# Altium schematic sheet vfd.SchDoc
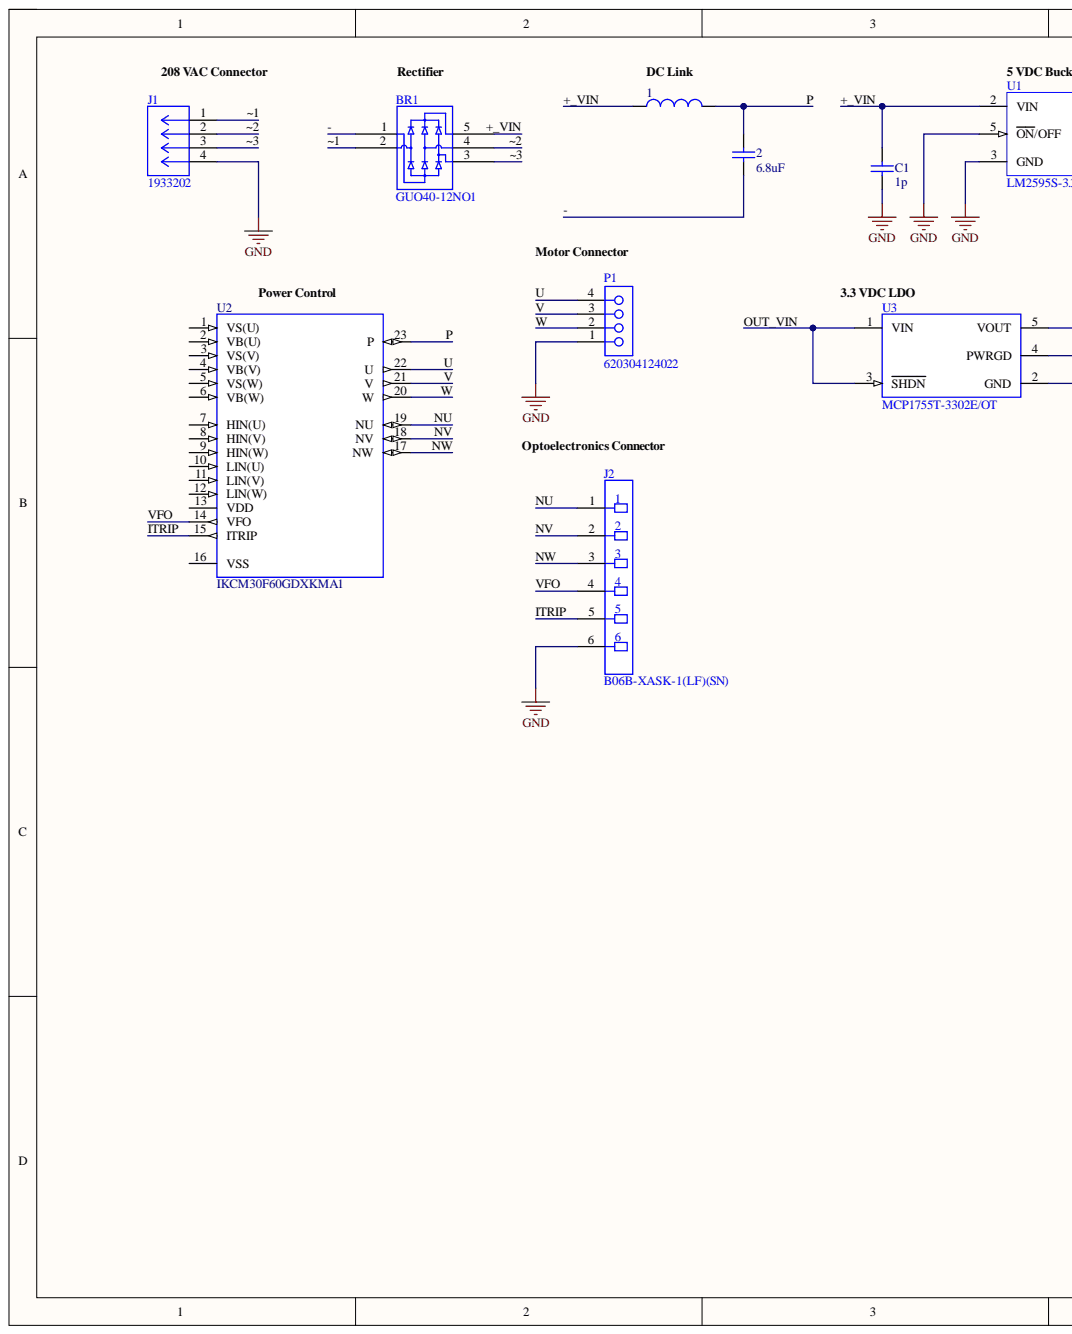
[diagram p0: vfd.SchDoc, left]
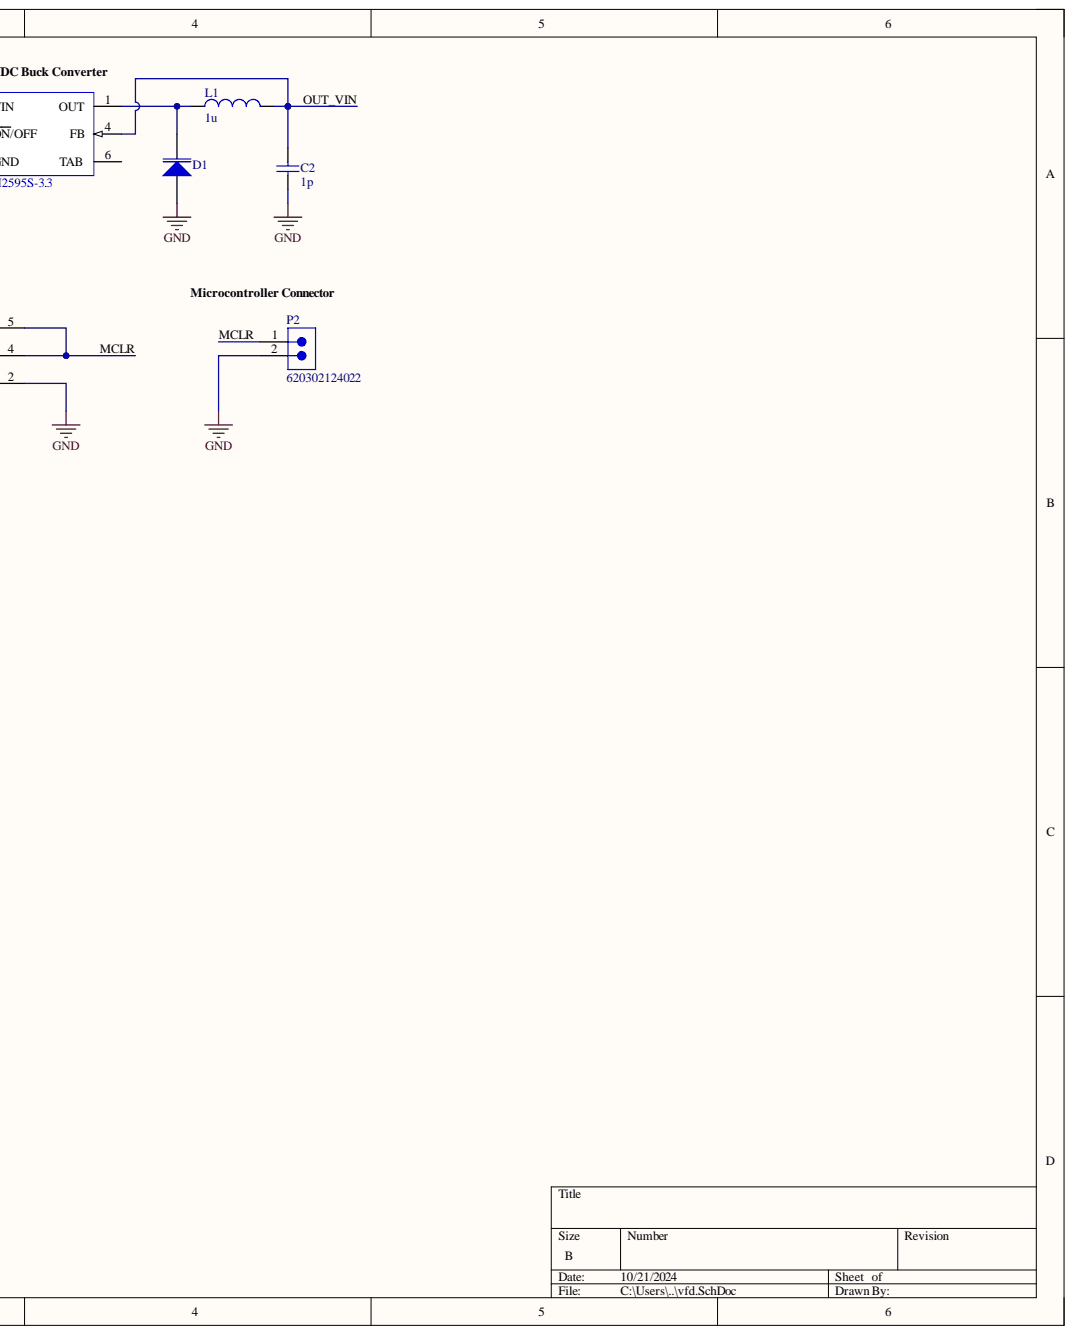
[diagram p1: vfd.SchDoc, right]

## Parts
1  Inductor  (value not drawn)
2  value 6.8uF  (hidden comment: Capacitor)
BR1  GUO40-12NO1  [SIP5-GUFP_LTF]
C1  value 1p  (hidden comment: Capacitor)
C2  value 1p  (hidden comment: Capacitor)
D1  Varactor  (value not drawn)
J1  1933202  [CONN_1933202_PXC]
J2  B06B-XASK-1(LF)(SN)  [FP-B06B-XASK-1_LF_SN-MFG]
L1  value 1u  (hidden comment: Inductor)
P1  620304124022  [620304124022]
P2  620302124022  [620302124022]
U1  LM2595S-3.3  [KTT0005B_L]
U2  IKCM30F60GDXKMA1  [IC_IKCM30F60GDXKMA1]
U3  MCP1755T-3302E/OT  [FP-C04-091-OT-MFG]

## Nets
GND: C1.1 C2.1 D1.3 J1.4 J2.6 P1.1 P2.2 U1.3(GND) U1.5(ON/OFF) U3.2(GND)
+_VIN: 1.1 BR1.5 C1.2 U1.2(VIN)
-: 2.1 BR1.1
ITRIP: J2.5 U2.15(ITRIP)
MCLR: P2.1 U3.4(PWRGD) U3.5(VOUT)
NU: J2.1 U2.19(NU)
NV: J2.2 U2.18(NV)
NW: J2.3 U2.17(NW)
OUT_VIN: C2.2 L1.2 U1.4(FB) U3.1(VIN) U3.3(SHDN)
P: 1.2 2.2 U2.23(P)
U: P1.4 U2.22(U)
V: P1.3 U2.21(V)
VFO: J2.4 U2.14(VFO)
W: P1.2 U2.20(W)
~1: BR1.2 J1.1
~2: BR1.4 J1.2
~3: BR1.3 J1.3
NetD1_1: D1.1 L1.1 U1.1(OUT)

Not connected: U1 6(TAB); U2 1(VS(U)) 2(VB(U)) 3(VS(V)) 4(VB(V)) 5(VS(W)) 6(VB(W)) 7(HIN(U)) 8(HIN(V)) 9(HIN(W)) 10(LIN(U)) 11(LIN(V)) 12(LIN(W)) 13(VDD) 16(VSS)

## Library data of the parts
1 L1 = Inductor: SimulationGenericComponent: True; Component Kind: Standard; Description: Inductor; Library Name: Simulation Generic Components.IntLib; Simulation: L_Inductor_Default
2 C1 C2 = Capacitor: SimulationGenericComponent: True; Component Kind: Standard; Description: Capacitor; Library Name: Simulation Generic Components.IntLib; Simulation: C_Capacitor_Default
BR1 = GUO40-12NO1: No Description Available; Mfr_Name: Littelfuse Inc; Component Kind: Standard; Library Name: GUO40-12NO1.IntLib; RefDes: RefDes; Type: Type
D1 = Varactor: AF: 1; BV: 0; BV_MAX: 1e99; EG: 1.11; FC: 0.5; IBV: 0.001; IS: 1e-14; MJSW: 0.33; NTUN: 30; SimulationGenericComponent: True; TNOM: 27; XTI: 3; CJO: 0; CJP: 0; Component Kind: Standard; CTA: 0; CTP: 0; Description: Varactor; FCS: 0.5; FV_MAX: 1e99; IKF: 0; IKR: 0; ISR: 1e-14; JSW: 0; JTUN: 0; JTUNSW: 0; KEG: 1; KF: 0; LEVEL: 1; Library Name: Simulation Generic Components.IntLib; N: 1; NBV: 1; NR: 1; NS: 1; PHP: 1; RS: 0; Simulation: diode; TCV: 0; TLEV: 0; TLEVC: 0; TM1: 0; TM2: 0; TPB: 0; TPHP: 0; TRS: 0; TRS2: 0; TT: 0; TTT1: 0; TTT2: 0; VJ: 1; XTITUN: 3
J1 = 1933202: No Description Available; Mfr_Name: Phoenix Contact; Component Kind: Standard; Library Name: 1933202.SchLib; RefDes: RefDes; Type: Type
J2 = CMP-17439-000268-1: CONN HEADER VERT 6POS 2.5MM; Contact Resistance: 20mR; Current Rating: 3A; Gender: Male; Insulation Resistance: 1GR; Lead Length: 3.4mm; Mated Stacking Heights: 11.3mm; Max Voltage Rating (AC): 250V; Number of Contacts: 6; Wire/Cable Gauge: 20AWG; Color: Beige; Component Kind: Standard; Connector Type: Header; Contact Finish - Mating: TIN; Contact Material: Copper Alloy; Contact Plating: Tin; Contact Shape: Circular; Contact Type: Male Pin; Depth: 6.4mm; Fastening Type: Ramp; Flammability Rating: UL94 V-0; Height: 8.8mm; Housing Color: Beige; Housing Material: Polyamide; Insulation Color: Natural; Insulation Material: Nylon; Lead Free: Lead Free; Length: 17.5mm; Library Name: Altium Content Vault; Material: Nylon; Max Current Rating: 3A; Max Operating Temperature: 85°C; Min Operating Temperature: -25°C; Mount: Through Hole; Number of Pins: 6; Number of Poles: 6; Number of Positions: 6; Number of Rows: 1; Orientation: Straight; Packaging: Bulk; Pitch: 2.5mm; REACH SVHC: No SVHC; Series: XA; Termination: Solder; Voltage Rating: 250V; Voltage Rating (AC): 250V; Voltage Rating (DC): 250V; Width: 6.4mm
P1 = CMP-1502-00662-2: NexarPartId: 18818244; Connector Type: Male Pin; Contact Resistance: 20mΩ; Current Rating: 2A; Gender: Male; Insulation: Insulated; Insulation Resistance: 1GΩ; Number of Contacts: 4; Operating Supply Voltage: 100V; Pitch: 2mm; Voltage Rating: 125V; Color: Beige, White; Component Kind: Standard; Contact Gender: Male; Contact Material: Copper; Contact Mating Length: 3.5052mm; Contact Plating: Tin; Flammability Rating: UL94 V-0; Height: 6.6mm; Housing Material: Nylon; Lead Free: Lead Free; Lead Pitch: 2mm; Length: 9mm; Library Name: Altium Content Vault; Max Operating Temperature: 85°C; Min Operating Temperature: -40°C; Mount: Surface Mount; Number of Pins: 4; Number of Positions: 4; Number of Rows: 1; Orientation: Vertical; Packaging: Tape and Reel; REACH SVHC: Unknown; RoHS: Compliant; Termination: SMD/SMT; Width: 11.9mm
P2 = CMP-1502-00660-2: NexarPartId: 18818242; Connector Type: Male Pin; Contact Resistance: 20mΩ; Current Rating: 2A; Gender: Male; Insulation: Insulated; Insulation Resistance: 1GΩ; Number of Contacts: 2; Operating Supply Voltage: 100V; Pitch: 2mm; Voltage Rating: 125V; Color: Beige, White; Component Kind: Standard; Contact Gender: Male; Contact Material: Copper; Contact Mating Length: 3.5052mm; Contact Plating: Tin; Flammability Rating: UL94 V-0; Height: 6.6mm; Housing Material: Nylon; Lead Pitch: 2mm; Length: 5mm; Library Name: Altium Content Vault; Max Operating Temperature: 85°C; Min Operating Temperature: -40°C; Mount: Surface Mount; Number of Pins: 2; Number of Positions: 2; Number of Rows: 1; Orientation: Vertical; Packaging: Tape and Reel; REACH SVHC: Unknown; RoHS: Compliant; Termination: SMD/SMT; Width: 7.9mm
U1 = CMP-0069-00223-3: SIMPLE SWITCHER® Power Converter 150 KHz 1A Step-Down Voltage Regulator, 5-pin TO-263; Manufacturer: Texas Instruments; PackageTopMarking: NSUZXYTTE#*LM2595S*-3.3 P+; PowerWise: N; Code_JEDEC: MO-169-AB; Component Kind: Standard; ComponentLink1Description: Datasheet URL; ComponentLink1URL: http://www.ti.com/general/docs/lit/getliterature.tsp?genericPartNumber=LM2595&fileType=pdf; CompType: Switcher; Library Name: Altium Content Vault; PackageDescription: TO-263, Molded, .400 x .390 x .180in, 5 Lead, .067in Pitch, Surface Mount; PackageReference: KTT0005B; PinCount: 5; RoHS: N
U2 = IKCM30F60GDXKMA1: Fully isolated Dual In-Line molded module; MF: Infineon Technologies; Availability: In Stock; Check_prices: https://www.snapeda.com/parts/IKCM30F60GDXKMA1/Infineon+Technologies/view-part/?ref=eda; Component Kind: Standard; Library Name: IKCM30F60GDXKMA1.SchLib; MP: IKCM30F60GDXKMA1; Package: PowerDIP-24 Infineon; Price: None; Purchase-URL: https://pricing.snapeda.com/search?q=IKCM30F60GDXKMA1&ref=eda; SnapEDA_Link: https://www.snapeda.com/parts/IKCM30F60GDXKMA1/Infineon+Technologies/view-part/?ref=snap
U3 = CMP-06324-000083-1: IC REG LINEAR 3.3V 300MA SOT23-5; Accuracy: 2%; Dropout Voltage: 300mV; Max Input Voltage: 16V; Min Input Voltage: 3.6V; Nominal Supply Current: 68uA; Number of Outputs: 1; Output Type: Fixed; Polarity: Positive; Power Supply Rejection Ratio (PSRR): 80dB; Quiescent Current: 100uA; Case/Package: SOT-23-5; Component Kind: Standard; Lead Free: Lead Free; Library Name: Altium Content Vault; Max Operating Temperature: 125°C; Max Output Current: 300mA; Min Operating Temperature: -40°C; Mount: Surface Mount; Nominal Output Voltage: 3.3V; Number of Pins: 5; Number of Regulators: 1; Output Current: 300mA; Output Voltage: 3.3V; Output Voltage Accuracy: 2%; Package Quantity: 3000; Packaging: Tape and Reel; Radiation Hardening: No; REACH SVHC: No SVHC; RoHS Compliant: Yes; Series: -; Supply Current: 68uA; Tolerance: 2%
